# Altium schematic sheet PowerSupply.SchDoc(PowerSupply)
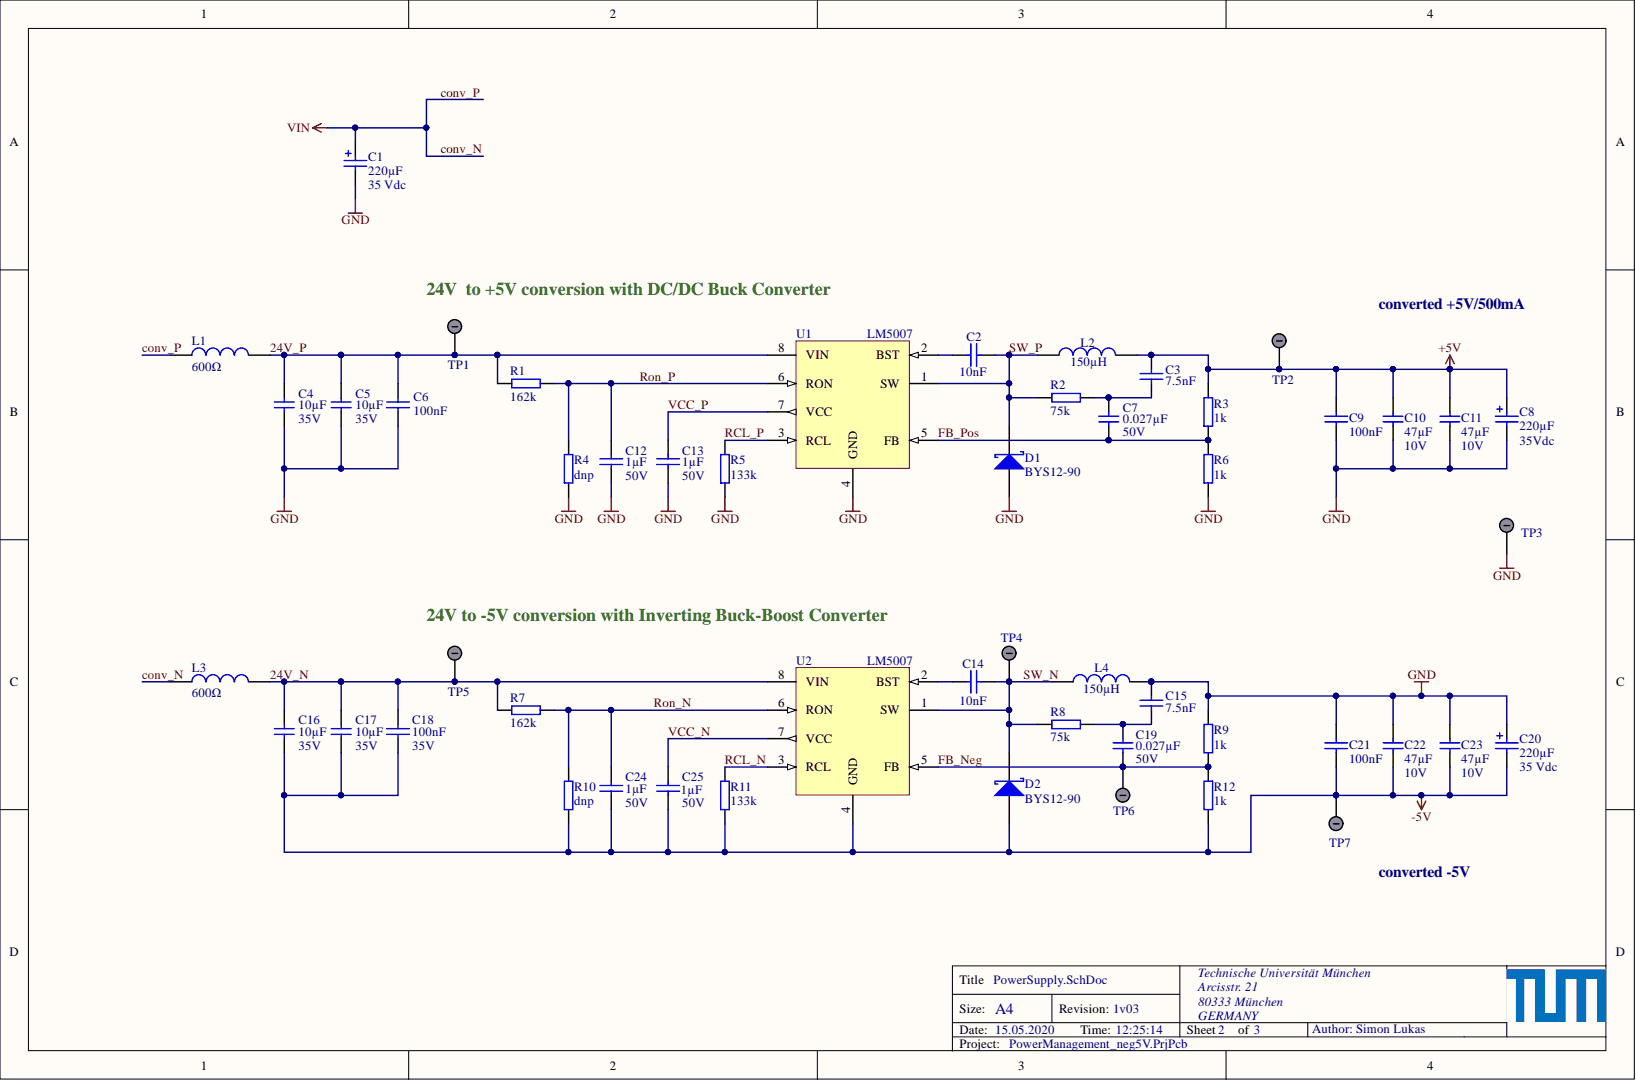
[diagram p0: PowerSupply.SchDoc]

## Parts
C1  220µF  Voltage: 35 Vdc  [WCAP-ATG5_8x11.5x3.5]
C2  10nF  [CAPC1608X07N]
C3  7.5nF  [CAPC1608X07N]
C4  10µF  Voltage: 35V  [C_1206]
C5  10µF  Voltage: 35V  [C_1206]
C6  100nF  [CAPC1608X07N]
C7  0.027µF  Voltage: 50V  [C_1206]
C8  220µF  Voltage: 35Vdc  [WCAP-ATG5_8x11.5x3.5]
C9  100nF  [CAPC1608X07N]
C10  47µF  Voltage: 10V  [C_1206]
C11  47µF  Voltage: 10V  [C_1206]
C12  1µF  Voltage: 50V  [CAPC1608X07N]
C13  1µF  Voltage: 50V  [CAPC1608X07N]
C14  10nF  [CAPC1608X07N]
C15  7.5nF  [CAPC1608X07N]
C16  10µF  Voltage: 35V  [C_1206]
C17  10µF  Voltage: 35V  [C_1206]
C18  100nF  Voltage: 35V  [CAPC1608X07N]
C19  0.027µF  Voltage: 50V  [C_1206]
C20  220µF  Voltage: 35 Vdc  [WCAP-ATG5_8x11.5x3.5]
C21  100nF  [CAPC1608X07N]
C22  47µF  Voltage: 10V  [C_1206]
C23  47µF  Voltage: 10V  [C_1206]
C24  1µF  Voltage: 50V  [CAPC1608X07N]
C25  1µF  Voltage: 50V  [CAPC1608X07N]
D1  BYS12-90  [DIOM4227X23N]
D2  BYS12-90  [DIOM4227X23N]
L1  600Ω  [WE-CBF_0805_High Current]
L2  150µH  [SMT_inductor_1050_Performance]
L3  600Ω  [WE-CBF_0805_High Current]
L4  150µH  [SMT_inductor_1050_Performance]
R1  162k  [RESC1608X06N]
R2  75k  [RESC1608X06N]
R3  1k  [RESC1608X06N]
R4  dnp  [RESC1608X06N]
R5  133k  [RESC1608X06N]
R6  1k  [RESC1608X06N]
R7  162k  [RESC1608X06N]
R8  75k  [RESC1608X06N]
R9  1k  [RESC1608X06N]
R10  dnp  [RESC1608X06N]
R11  133k  [RESC1608X06N]
R12  1k  [RESC1608X06N]
TP1  24V_P  [TP_5006]  (value not drawn)
TP2  +5V  [TP_5006]  (value not drawn)
TP3  GND  [TP_5006]
TP4  SW_N  [TP_5006]
TP5  24V_N  [TP_5006]  (value not drawn)
TP6  FB_N  [TP_5006]  (value not drawn)
TP7  -5V  [TP_5006]  (value not drawn)
U1  LM5007  [TSOP65P490X110-8N]
U2  LM5007  [TSOP65P490X110-8N]

## Nets
+5V: C3.1 C8.1 C9.2 C10.2 C11.2 L2.2 R3.2 TP2.1
-5V: C16.1 C17.1 C18.2 C20.2 C21.1 C22.1 C23.1 C24.2 C25.2 D2.2 R10.1 R11.1 R12.2 TP7.1 U2.4(GND)
GND: C1.2 C4.1 C5.1 C6.2 C8.2 C9.1 C10.1 C11.1 C12.2 C13.2 C15.1 C20.1 C21.2 C22.2 C23.2 D1.2 L4.2 R4.1 R5.1 R6.1 R9.1 TP3.1 U1.4(GND)
24V_N: C16.2 C17.2 C18.1 L3.2 R7.2 TP5.1 U2.8(VIN)
24V_P: C4.2 C5.2 C6.1 L1.2 R1.1 TP1.1 U1.8(VIN)
conv_N: C1.1 L1.1 L3.1
FB_Neg: C19.2 R9.2 R12.1 TP6.1 U2.5(FB)
FB_Pos: C7.1 R3.1 R6.2 U1.5(FB)
RCL_N: R11.2 U2.3(RCL)
RCL_P: R5.2 U1.3(RCL)
Ron_N: C24.1 R7.1 R10.2 U2.6(RON)
Ron_P: C12.1 R1.2 R4.2 U1.6(RON)
SW_N: C14.1 D2.1 L4.1 R8.2 TP4.1 U2.1(SW)
SW_P: C2.2 D1.1 L2.1 R2.1 U1.1(SW)
VCC_N: C25.1 U2.7(VCC)
VCC_P: C13.1 U1.7(VCC)
NetC2_1: C2.1 U1.2(BST)
NetC3_2: C3.2 C7.2 R2.2
NetC14_2: C14.2 U2.2(BST)
NetC15_2: C15.2 C19.1 R8.1

## Library data of the parts
C1 C8 C20 = 860020574012: WCAP-ATG5 Aluminum Electrolytic Capacitors, 8x11.5mm, 35 Vdc, 220 uF; MF: Würth Elektronik; Value: 220 µF; Supplier Part Number 1: 710-860020574012; Supplier 1: Mouser; Size: 8x11.5mm; Part Number: 860020574012; Operating Temperature: -40 °C up to +105 °C; MPN: 860020574012; Life Cycle: 2000h; ComponentLink2URL: http://katalog.we-online.com/pbs/datasheet/860020574012.pdf; ComponentLink2Description: Datasheet; ComponentLink1URL: http://www.we-online.de; ComponentLink1Description: Manufacturer; Component Kind: Standard; Library Name: neg5V.SCHLIB
C2 C14 = Cap_0603: MPN: 885012206065; Voltage: 25V; MF: Würth; Dielectric: X7R; Value: 10nF; Supplier Part Number 2: 732-7983-1-ND; Supplier Part Number 1: 710-885012206065; Supplier 2: Digi-Key; Supplier 1: Mouser; Package: 0603; Component Kind: Standard; Library Name: neg5V.SCHLIB
C3 C15 = Cap_0603: MPN: C0603C752J3GACTU; Voltage: 25V; Tolerance: 5%; MF: KEMET; Dielectric: C0G; Value: 7.5nF; Supplier Part Number 1: 80-C0603C752J3GACTU; Supplier 1: Mouser; Package: 0603; Component Kind: Standard; Library Name: neg5V.SCHLIB
C4 C5 C16 C17 = C_1206: Capacitor 1206; MPN: GRT31CC8YA106KE01L; Tolerance: 10%; MF: Murata Electronics; Dielectric: X6S; Value: 10µF; Supplier Part Number 1: 81-GRT31CC8YA106KE1L; Supplier 1: Mouser; Package: 1206; Component Kind: Standard; Library Name: neg5V.SCHLIB
C6 = Cap_0603: MPN: 885012206071; Voltage: 25V; MF: Würth; Dielectric: X7R; Value: 100nF; Supplier Part Number 2: 732-7989-1-ND; Supplier Part Number 1: 710-885012206071; Supplier 2: Digi-Key; Supplier 1: Mouser; Package: 0603; Component Kind: Standard; Library Name: neg5V.SCHLIB
C7 C19 = C_1206: Capacitor 1206; MPN: GRM3195C1H273JA01D; Tolerance: 5%; MF: Murata Electronics; Dielectric: C0G; Value: 0.027µF; Supplier Part Number 1: 81-GRM315C1H273JA01D; Supplier 1: Mouser; Package: 1206; Component Kind: Standard; Library Name: neg5V.SCHLIB
C9 C21 = Cap_0603: MPN: 885012206071; Voltage: 25V; Tolerance: 10%; MF: Würth; Dielectric: X7R; Value: 100nF; Supplier Part Number 1: 710-885012206071; Supplier 1: Mouser; Package: 0603; Component Kind: Standard; Library Name: neg5V.SCHLIB
C10 C11 C22 C23 = C_1206: Capacitor 1206; MPN: GRM31CR61A476ME15L; Tolerance: 20%; MF: Murata Electronics; Dielectric: X5R; Value: 47µF; Supplier Part Number 1: 81-GRM31CR61A476ME5L; Supplier 1: Mouser; Package: 1206; Component Kind: Standard; Library Name: neg5V.SCHLIB
C12 C13 C24 C25 = Cap_0603: MPN: GRT188R61H105KE13D; MF: Murata Electronics; Dielectric: X5R; Value: 1µF; Supplier Part Number 2: 490-12330-1-ND; Supplier Part Number 1: 81-GRT188R61H105KE3D; Supplier 2: Digi-Key; Supplier 1: Mouser; Package: 0603; Component Kind: Standard; Library Name: neg5V.SCHLIB
C18 = Cap_0603: MPN: GMK107B7104KAHT; Tolerance: 10%; MF: Taiyo Yuden; Dielectric: X7R; Value: 100nF; Supplier Part Number 2: 587-3354-1-ND; Supplier Part Number 1: 963-GMK107B7104KAHT; Supplier 2: Digi-Key; Supplier 1: Mouser; Package: 0603; Component Kind: Standard; Library Name: neg5V.SCHLIB
D1 D2 = BYS12-90: Schottky Diode; MPN: BYS12-90-E3/TR3; Reverse Voltage: 90V; Rated Current: 1.5A; MF: Vishay; Forward Voltage: 750mV; Supplier Part Number 3: BYS12-90-E3/GICT-ND; Supplier Part Number 2: 625-BYS12-90-E3/TR3; Supplier Part Number 1: 2889144; Supplier 3: Digi-Key; Supplier 2: Mouser; Supplier 1: Farnell; Package: DO-214AC; Component Kind: Standard; Library Name: neg5V.SCHLIB
L1 = WE-CBF, 742792040: WE-CBF SMD EMI Suppression Ferrite Bead, 0805, 600Ω; Type: High Current; MF: Würth Elektronik; Value: 600Ω; Supplier Part Number 3: 732-1620-1-ND; Supplier Part Number 2: 710-742792040; Supplier Part Number 1: 2761567; Supplier 3: Digi-Key; Supplier 2: Mouser; Supplier 1: Farnell; Size: 0805; MPN: 742792040; ComponentLink2URL: http://katalog.we-online.de/pbs/datasheet/742792040.pdf; ComponentLink2Description: Datasheet; ComponentLink1URL: http://www.we-online.de; ComponentLink1Description: Manufacturer; Component Kind: Standard; Library Name: neg5V.SCHLIB
L2 L4 = B82464G4154M: SMT power Inductor, 150uH, 320m DRC; Tolerance: 20%; Rated Current: 0.85 A; MF: EPCOS / TDK; Value: 150µH; Supplier Part Number 1: 871-B82464G4154M; Supplier 1: Mouser; MPN: B82464G4154M; DCR: 320m; Component Kind: Standard; Library Name: neg5V.SCHLIB
L3 = WE-CBF, 742792040: WE-CBF SMD EMI Suppression Ferrite Bead, 0805, 600Ω; Type: High Current; MF: Wurth Elektronik; Value: 600Ω; Supplier Part Number 3: 732-1620-1-ND; Supplier Part Number 2: 710-742792040; Supplier Part Number 1: 1635735; Supplier 3: Digi-Key; Supplier 2: Mouser; Supplier 1: Farnell; Size: 0805; MPN: 742792040; ComponentLink2URL: http://katalog.we-online.de/pbs/datasheet/742792040.pdf; ComponentLink2Description: Datasheet; ComponentLink1URL: http://www.we-online.de; ComponentLink1Description: Manufacturer; Component Kind: Standard; Library Name: neg5V.SCHLIB
R1 R7 = R_0603: MPN: CR0603-FX-1623ELF; MF: Bourns; Value: 162k; Supplier Part Number 1: 652-CR0603-FX1623ELF; Supplier 1: Mouser; Package: 0603; Component Kind: Standard; Library Name: neg5V.SCHLIB
R2 R8 = R_0603: MPN: AC0603FR-1375KL; MF: Yageo; Value: 75k; Supplier Part Number 1: 603-AC0603FR-1375KL; Supplier 1: Mouser; Package: 0603; Component Kind: Standard; Library Name: neg5V.SCHLIB
R3 R6 R9 R12 = R_0603: MPN: CRCW06031K00JNEAC; Tolerance: 5%; MF: Vishay / Dale; Value: 1k; Supplier Part Number 1: 71-CRCW06031K00JNEAC; Supplier 1: Mouser; Package: 0603; Component Kind: Standard; Library Name: neg5V.SCHLIB
R4 R10 = R_0603: Value: dnp; Package: 0603; Component Kind: Standard; Library Name: neg5V.SCHLIB
R5 R11 = R_0603: MPN: CR0603-FX-1333ELF; MF: Bourns; Value: 133k; Supplier Part Number 1: 652-CR0603FX-1333ELF; Supplier 1: Mouser; Package: 0603; Component Kind: Standard; Library Name: neg5V.SCHLIB
TP1-TP7 = 5006: Test Point;  Compact;  THM;  PC;  .350 L;  .125 OD;  Heat Resistant Nylon 46;  Black; Manufacturer: Keystone Electronics; Plating: Silver; Outside Diameter: 3.18mm; Number of Ports: 1; Inside Diameter: 1.78mm; Hole Diameter: 1.6mm; Body Material: PBT; Supplier Part Number 1: 534-5006; Supplier 1: Mouser; Stock 1: 203116; RoHS: Yes; REACH SVHC: No SVHC; Packaging: Bulk; Package Quantity: 100; Orientation: Straight; Mount: PCB,Through Hole; Material: Nylon,Bronze,Silver; Manufacturer Part Number: 5006; Length: 8.89mm; Lead Free: Lead Free; Housing Color: Black; Height: 0.35inch; Flammability Rating: UL94 V-0; Contact Plating: Tin,Silver; Contact Material: Bronze; ComponentLink5URL: https://datasheet.ciiva.com/16883/70182825-16883963.pdf?src-supplier=Allied+Electronics; ComponentLink5Description: https://datasheet.ciiva.com/16883/70182825-16883963.pdf?src-supplier=Allied+Electronics; ComponentLink4URL: https://datasheet.ciiva.com/2917/m60-2p60-2917629.pdf?src-supplier=Digi-Key; ComponentLink4Description: https://datasheet.ciiva.com/2917/m60-2p60-2917629.pdf?src-supplier=Digi-Key; ComponentLink3URL: https://datasheet.ciiva.com/11744/0900766b8009b7c7-11744947.pdf?src-supplier=RSComponents; ComponentLink3Description: https://datasheet.ciiva.com/11744/0900766b8009b7c7-11744947.pdf?src-supplier=RSComponents; ComponentLink2URL: https://datasheet.ciiva.com/1454/1718283-1454829.pdf?src-supplier=Element14; ComponentLink2Description: https://datasheet.ciiva.com/1454/1718283-1454829.pdf?src-supplier=Element14; ComponentLink1URL: https://datasheet.ciiva.com/1123/m60-2p60-1123808.pdf?src-supplier=Newark; ComponentLink1Description: https://datasheet.ciiva.com/1123/m60-2p60-1123808.pdf?src-supplier=Newark; Component Kind: Standard; Color: Black; Category: Connectors; Library Name: neg5V.SCHLIB
U1 U2 = LM5007: Buck Converter, 9-75Vin, 2.5-73Vout; MPN: LM5007MM/NOPB; MF: Texas Instruments; Supplier Part Number 3: 296-LM5007MM/NOPBCT-ND; Supplier Part Number 2: 926-LM5007MM/NOPB; Supplier Part Number 1: 3007210; Supplier 3: Digi-Key; Supplier 2: Mouser; Supplier 1: Farnell; Package: VSSOP-8; Component Kind: Standard; Library Name: neg5V.SCHLIB
